# Altium schematic sheet CANFD.SchDoc(CANFD)
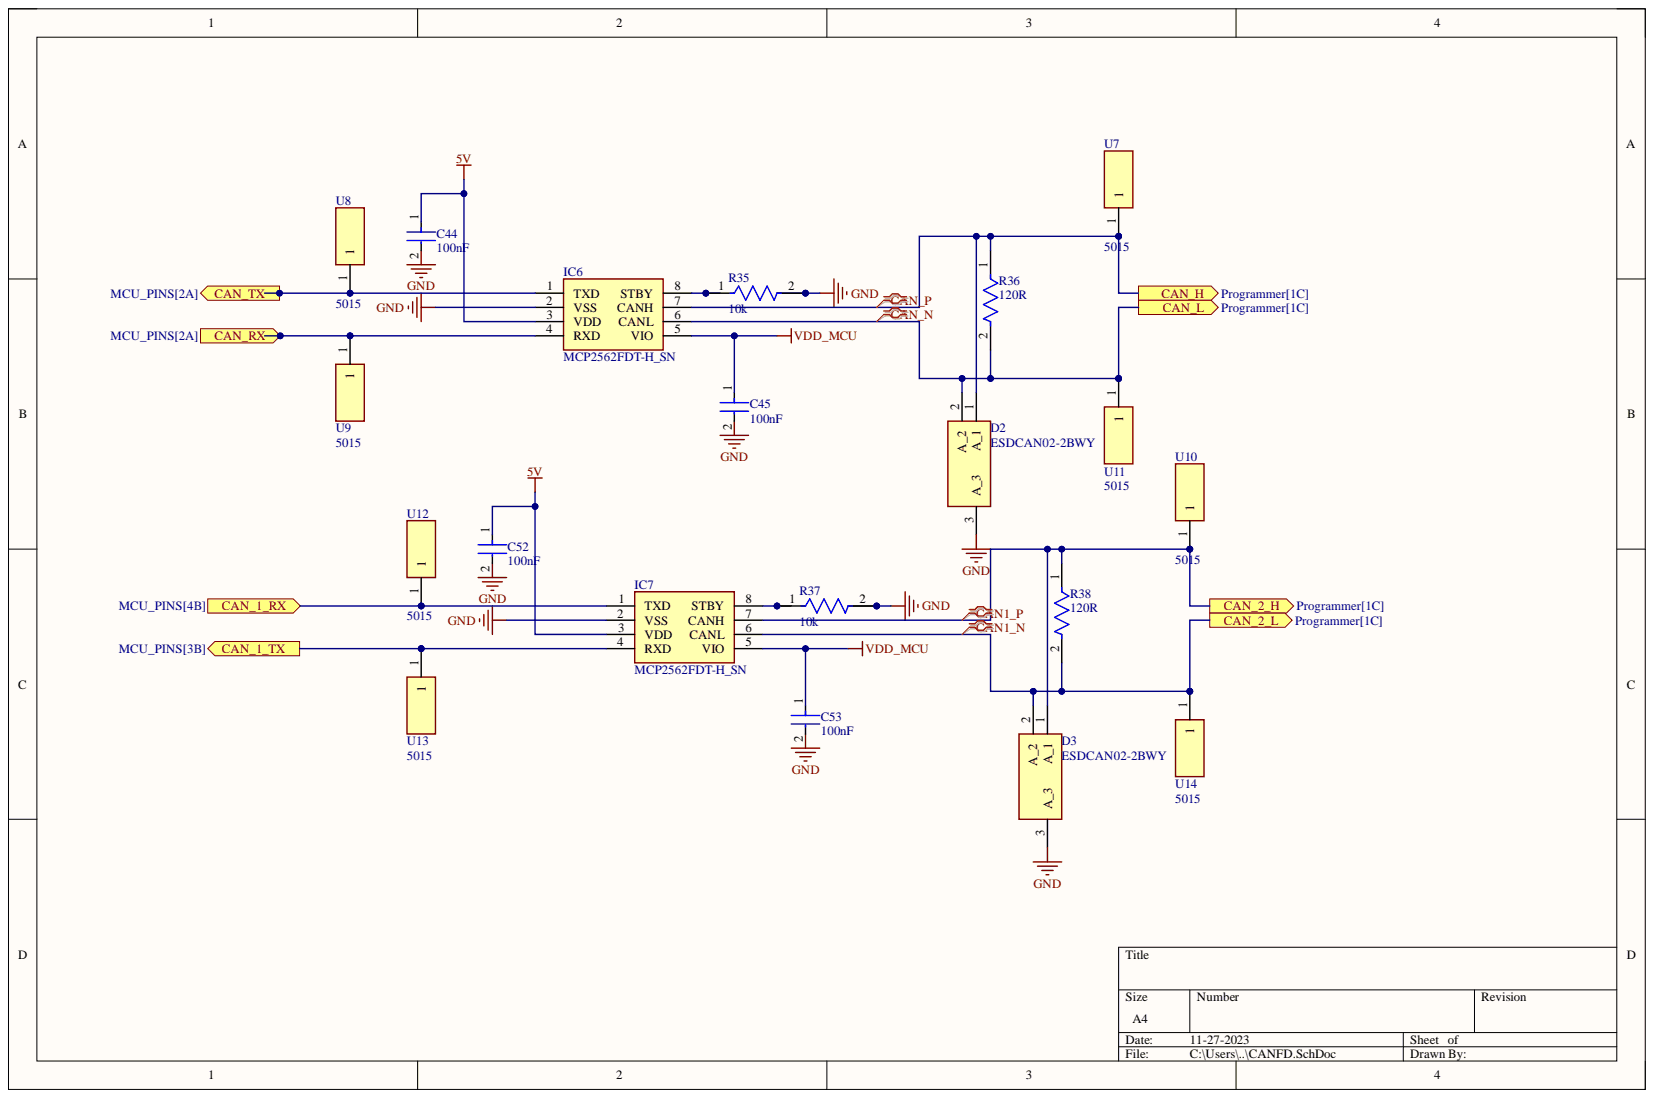
[diagram p0: CANFD.SchDoc]

## Parts
C44  100nF  [FP-C0603C-CF-MFG]
C45  100nF  [FP-C0603C-CF-MFG]
C52  100nF  [FP-C0603C-CF-MFG]
C53  100nF  [FP-C0603C-CF-MFG]
D2  ESDCAN02-2BWY  [SOT65P210X110-3N]
D3  ESDCAN02-2BWY  [SOT65P210X110-3N]
IC6  MCP2562FDT-H_SN  [SOIC127P600X175-8N]
IC7  MCP2562FDT-H_SN  [SOIC127P600X175-8N]
R35  10k  [FP-RT0603-MFG]
R36  120R  [FP-RT0603-MFG]
R37  10k  [FP-RT0603-MFG]
R38  120R  [FP-RT0603-MFG]
U7  5015  [5015]
U8  5015  [5015]
U9  5015  [5015]
U10  5015  [5015]
U11  5015  [5015]
U12  5015  [5015]
U13  5015  [5015]
U14  5015  [5015]

## Ports
CAN_1_RX, CAN_1_TX, CAN_2_H, CAN_2_L, CAN_H, CAN_L, CAN_RX, CAN_TX

## Nets
5V: C44.1 C52.1 IC6.3(VDD) IC7.3(VDD)
GND: C44.2 C45.2 C52.2 C53.2 D2.3 D3.3 IC6.2(VSS) IC7.2(VSS) R35.2 R37.2
VDD_MCU: C45.1 C53.1 IC6.5(VIO) IC7.5(VIO)
CAN1_N: D3.2 IC7.6(CANL) R38.2 U14.1 ; port CAN_2_L
CAN1_P: D3.1 IC7.7(CANH) R38.1 U10.1 ; port CAN_2_H
CAN_1_RX: IC7.1(TXD) U12.1 ; port CAN_1_RX
CAN_1_TX: IC7.4(RXD) U13.1 ; port CAN_1_TX
CAN_N: D2.2 IC6.6(CANL) R36.2 U11.1 ; port CAN_L
CAN_P: D2.1 IC6.7(CANH) R36.1 U7.1 ; port CAN_H
CAN_RX: IC6.4(RXD) U9.1 ; port CAN_RX
CAN_TX: IC6.1(TXD) U8.1 ; port CAN_TX
NetIC6_8: IC6.8(STBY) R35.1
NetIC7_8: IC7.8(STBY) R37.1

## Library data of the parts
C44 C45 C52 C53 = CMP-2006-03061-2: CAP CER 0.1UF 50V X7R 0603; Manufacturer: KEMET; Manufacturer Part Number: C0603C104K5RACTU; Automotive Grade: Grade 1; Capacitance: 0.10 μF; Material: Ceramic; Temperature Coefficient: 30ppm/°C; Tolerance: 0.1; Value: 0.10 μF; Automotive: Yes; Category: Cap; Component Kind: Standard; ComponentLink2Description: Datasheet; ComponentLink2URL: https://api.kemet.com/component-edge/download/datasheet/C0603C100J5GACAUTO.pdf; Device Class L1: Passive Components; Device Class L2: Capacitors; Device Class L3: Ceramic Capacitors; Digikey Description: CAP CER 0.1UF 50V X7R 0603; Height: 0.87mm; Lead Free: Yes; Library Name: Altium Content Vault; Octopart: https://octopart.com/c0603c104k5ractu-kemet-139244; Package: C0603C-CF; RoHS: TRUE; Temperature: -55°C to +125°C; Temperature Characteristic: X7R; Temperature Range High: +125°C; Temperature Range Low: -55°C; Voltage Rating: 50 V
D2 D3 = ESDCAN02-2BWY: TVS Diode (Bi-directional); Manufacturer_Name: STMicroelectronics; Arrow Part Number: ESDCAN02-2BWY; Arrow Price/Stock: https://www.arrow.com/en/products/esdcan02-2bwy/stmicroelectronics?region=nac; Component Kind: Standard; Datasheet Link: https://www.st.com/resource/en/datasheet/esdcan02-2bwy.pdf; Height: 1.1mm; Manufacturer_Part_Number: ESDCAN02-2BWY; Mouser Part Number: 511-ESDCAN02-2BWY; Mouser Price/Stock: https://www.mouser.co.uk/ProductDetail/STMicroelectronics/ESDCAN02-2BWY?qs=FqY30PPN9V14rBXzBYhRkA%3D%3D
IC6 IC7 = MCP2562FDT-H_SN: Integrated Circuit; Manufacturer_Name: Microchip; Manufacturer_Part_Number: MCP2562FDT-H/SN; Arrow Part Number: MCP2562FDT-H/SN; Arrow Price/Stock: https://www.arrow.com/en/products/mcp2562fdt-hsn/microchip-technology?region=nac; Component Kind: Standard; Datasheet Link: http://www.microchip.com/mymicrochip/filehandler.aspx?ddocname=en568915; Height: 1.75mm; Mouser Part Number: 579-MCP2562FDT-H/SN; Mouser Price/Stock: https://www.mouser.co.uk/ProductDetail/Microchip-Technology/MCP2562FDT-H-SN?qs=YlpJWyuQ3PMXmsmdO4TKrg%3D%3D
R35-R38 = CMP-03412-033768-1: RES SMD 2.2K OHM 1% 1/10W 0603; Manufacturer: Yageo; Manufacturer Part Number: RT0603FRE072K2L; Power Rating: 0.1W; Resistance: 2.2KΩ; Temperature Coefficient: 50 ppm/°C; Tolerance: 0.01; Value: 2.2KΩ; Voltage Rating: 75V; Automotive: No; Category: Res; Component Kind: Standard; ComponentLink2Description: Datasheet; ComponentLink2URL: http://www.yageo.com/documents/recent/PYu-RT_1-to-0.01_RoHS_L_9.pdf; Composition: Thin Film; Device Class L1: Passive Components; Device Class L2: Resistors; Device Class L3: Chip SMD Resistors; Digikey Description: RES SMD 2.2K OHM 1% 1/10W 0603; Footprint Url: http://www.yageo.com/exep/pages/download/literatures/PYu-R_Mount_10.pdf; Height: 0.55mm; Ipc Land Pattern Name: RESC16080X45; Lead Free: Yes; Library Name: Altium Content Vault; Mouser Description: High Precision-High Stability Chip Resistor Thin Film 0603 2.2kOhm 1% Paper T/R; Octopart: https://octopart.com/rt0603fre072k2l-yageo-40019811; Package: RT0603; RoHS: TRUE; Series: RT; Temperature: -55°C to +155°C; Temperature Range High: +155°C; Temperature Range Low: -55°C
U7-U14 = 5015: Undefined or Miscellaneous; Manufacturer_Name: Keystone Electronics; Arrow Part Number: 5015; Arrow Price/Stock: https://www.arrow.com/en/products/5015/keystone-electronics?region=europe; Component Kind: Standard; Datasheet Link: http://www.keyelco.com/product-pdf.cfm?p=1353; Height: 1.52mm; Manufacturer_Part_Number: 5015; Mouser Part Number: 534-5015; Mouser Price/Stock: https://www.mouser.co.uk/ProductDetail/Keystone-Electronics/5015?qs=qOqV1E1P08ShW56PtUq2FA%3D%3D
